# Altium schematic sheet Boost.SchDoc(U_Boost)
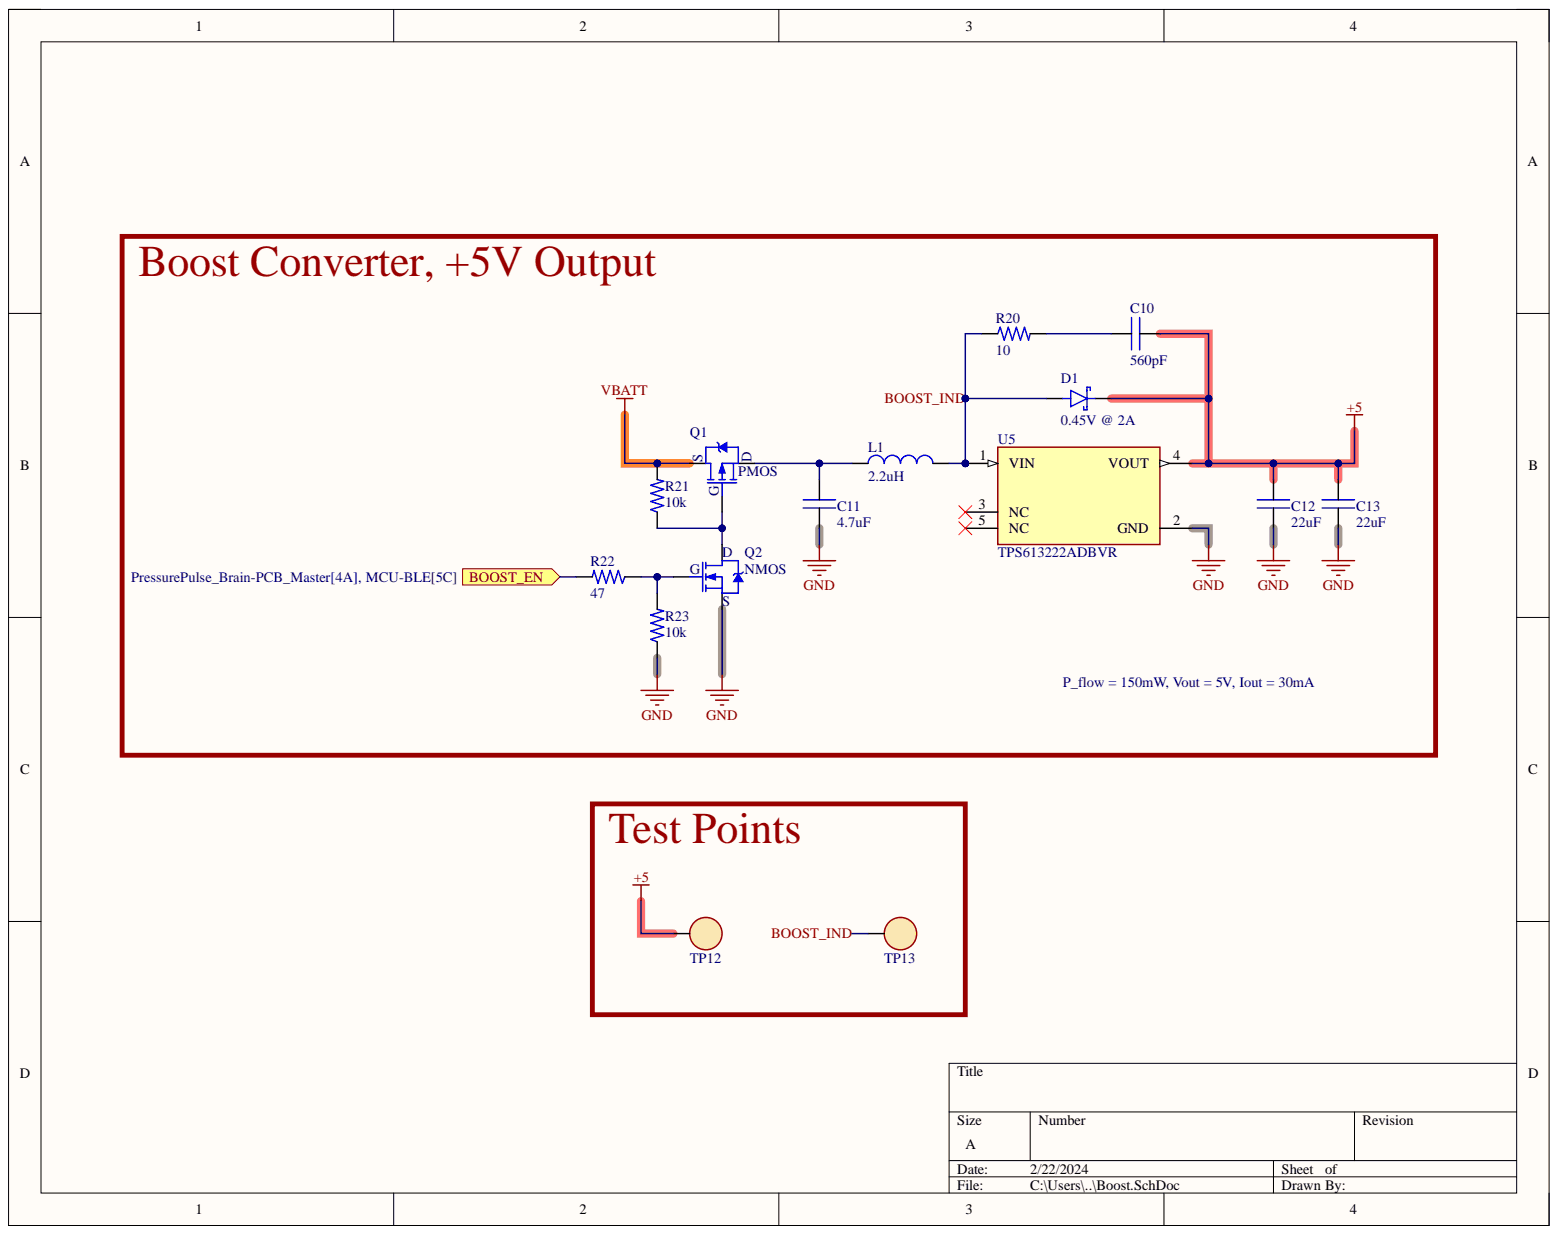
[diagram p0: Boost.SchDoc]

## Parts
C10  value 560pF  (hidden comment: CAP)
C11  value 4.7uF  (hidden comment: CAP)
C12  value 22uF  (hidden comment: CAP)
C13  value 22uF  (hidden comment: CAP)
D1  0.45V @ 2A  [SMAF]
L1  value 2.2uH  (hidden comment: IND)
Q1  PMOS
Q2  NMOS  [SOT-23]
R20  value 10  (hidden comment: RES)
R21  value 10k  (hidden comment: RES)
R22  value 47  (hidden comment: RES)
R23  value 10k  (hidden comment: RES)
TP12  RH-5019  [TP - RH-5019]  (value not drawn)
TP13  RH-5019  [TP - RH-5019]  (value not drawn)
U5  TPS613222ADBVR  [SOT-23-5]

## Ports
BOOST_EN

## Nets
+5: C10.2 C12.2 C13.2 D1.K TP12.1 U5.4(VOUT)
GND: C11.1 C12.1 C13.1 Q2.S R23.1 U5.2(GND)
VBATT: Q1.S R21.2
BOOST_EN: R22.2 ; port BOOST_EN
BOOST_IND: D1.A L1.2 R20.1 TP13.1 U5.1(VIN)
NetC10_1: C10.1 R20.2
NetC11_2: C11.2 L1.1 Q1.D
NetQ1_G: Q1.G Q2.D R21.1
NetQ2_G: Q2.G R22.1 R23.2
NetU5_3: U5.3(NC)
NetU5_5: U5.5(NC)

## Library data of the parts
C10 C11 = CAP: Capacitor; Component Kind: Standard; Library Name: PressurePulse.SchLib
  C10: JLCPCB PN: C5186812
C12 C13 = CAP: Capacitor; MFR: Samsung Electro-Mechanics; MFR PN: CL21A226MAQNNNE; Component Kind: Standard; JLCPCB PN: C45783; Library Name: PressurePulse.SchLib
D1 = SCHOTTKY DIODE: MFR: Shandong Jingdao Microelectronics; MFR PN: SSL24F; Value: SSL24F; Component Kind: Standard; JLCPCB PN: C4749014; Library Name: PressurePulse.SchLib
L1 = IND: INDUCTOR; MFR: Sunlord; MFR PN: WPN201610U2R2MT; Component Kind: Standard; JLCPCB PN: C71386; Library Name: PressurePulse.SchLib
Q1 = PMOS: P-Channel MOSFET; MFR: Diodes Incorporated; Value: PPMT12V4; Component Kind: Standard; JLCPCB PN: C110724; Library Name: PressurePulse.SchLib
Q2 = NMOS: 100V 1.6A 1.3W 220mΩ@10V,1.6A N Channel SOT-23  MOSFETs ROHS; MFR: Infineon; MFR PN: IRLML0100TRPBF; Value: IRLML0100TRPBF; Component Kind: Standard; JLCPCB PN: C53658; Library Name: PressurePulse.SchLib
R20-R23 = RES: Resistor; Component Kind: Standard; Library Name: PressurePulse.SchLib
  R20: JLCPCB PN: C3013385
TP12 TP13 = TEST POINT: MFR: ronghe; Component Kind: Standard; JLCPCB PN: C5199800; Library Name: PressurePulse.SchLib; MFR PN: RH-5019; Value: RH-5019
U5 = BOOST 3.3V-5V FIXED: 6-uA Quiescent Current, 1.8-A Switch Current Boost Converter 5-SOT-23 -40 to 85; MFR: Texas Instruments; Component Kind: Standard; JLCPCB PN: C2071163; Library Name: PressurePulse.SchLib; MFR PN: TPS613222ADBVR; Value: TPS613222ADBVR
